# Altium schematic sheet Sheet1.SchDoc
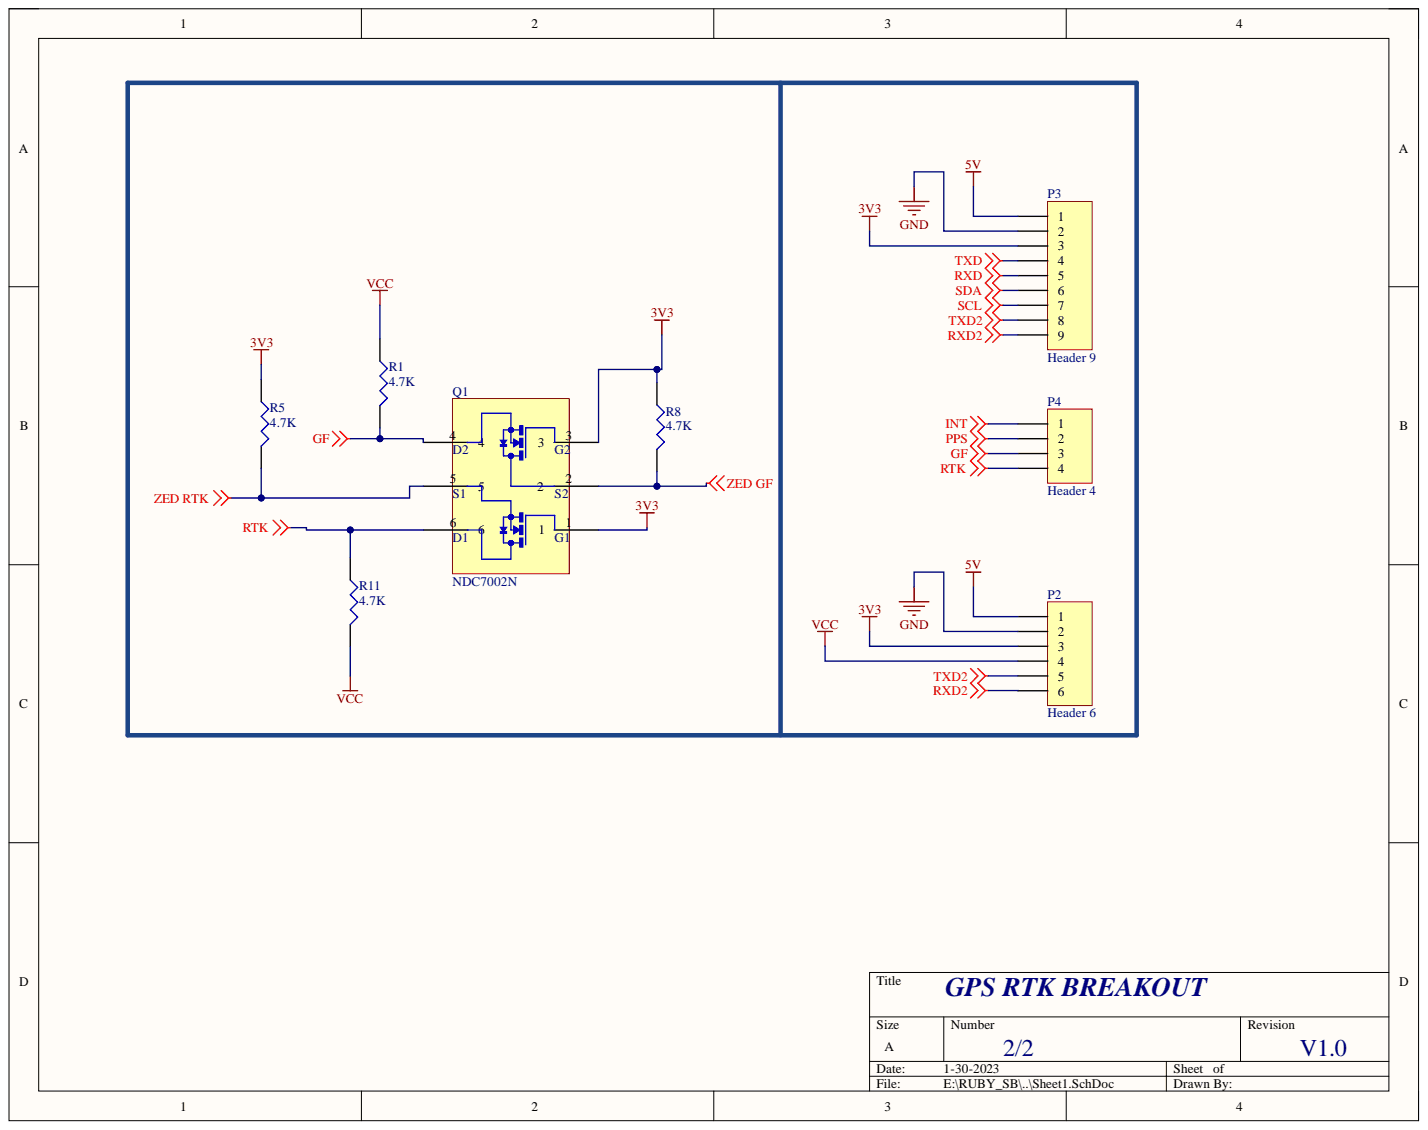
[diagram p0: Sheet1.SchDoc]

## Parts
P2  Header 6  [6P_2.54MM]
P3  Header 9  [9P_TH_2.54MM]
P4  Header 4  [4P_TH_2.54MM]
Q1  NDC7002N  [NDC7002]
R1  4.7K  [R_0603]
R5  4.7K  [R_0603]
R8  4.7K  [R_0603]
R11  4.7K  [0603]

## Nets
3V3: P2.3 P3.3 Q1.1 Q1.3 R5.1 R8.1
5V: P2.1 P3.1
GF: P4.3 Q1.4 R1.2
GND: P2.2 P3.2
INT: P4.1
PPS: P4.2
RTK: P4.4 Q1.6 R11.1
RXD: P3.5
RXD2: P2.6 P3.9
SCL: P3.7
SDA: P3.6
TXD: P3.4
TXD2: P2.5 P3.8
VCC: P2.4 R1.1 R11.2
ZED GF: Q1.2 R8.2
ZED RTK: Q1.5 R5.2

## Library data of the parts
P2 = Header 6: Header, 6-Pin; Component Kind: Standard; LatestRevisionDate: 17-Jul-2002; LatestRevisionNote: Re-released for DXP Platform.; Library Name: Miscellaneous Connectors.IntLib; Signal Integrity: Connector; Publisher: Altium Limited
P3 = Header 9: Header, 9-Pin; Component Kind: Standard; LatestRevisionDate: 17-Jul-2002; LatestRevisionNote: Re-released for DXP Platform.; Library Name: Miscellaneous Connectors.IntLib; Signal Integrity: Connector; Publisher: Altium Limited
P4 = Header 4: Header, 4-Pin; Component Kind: Standard; LatestRevisionDate: 17-Jul-2002; LatestRevisionNote: Re-released for DXP Platform.; Library Name: Miscellaneous Connectors.IntLib; Signal Integrity: Connector; Publisher: Altium Limited
Q1 = NDC7002N: Mosfet Array 2 N-Channel _Dual_ 50V 510mA 700mW Surface Mount SuperSOTâ„¢-6; MF: ON Semiconductor; Availability: Unavailable; Component Kind: Standard; MP: NDC7002N; Package: TSOT-23-6 ON Semiconductor; Price: None; SnapEDA_Link: https://www.snapeda.com/parts/NDC7002N/ON%20Semiconductor/view-part/2045881/?ref=snap; Library Name: dxp_pasl_lib.IntLib
R1 R5 R8 R11 = RES: Component Kind: Standard; Library Name: dxp_pasl_lib.IntLib
